# Altium schematic sheet 05-SPI.SchDoc
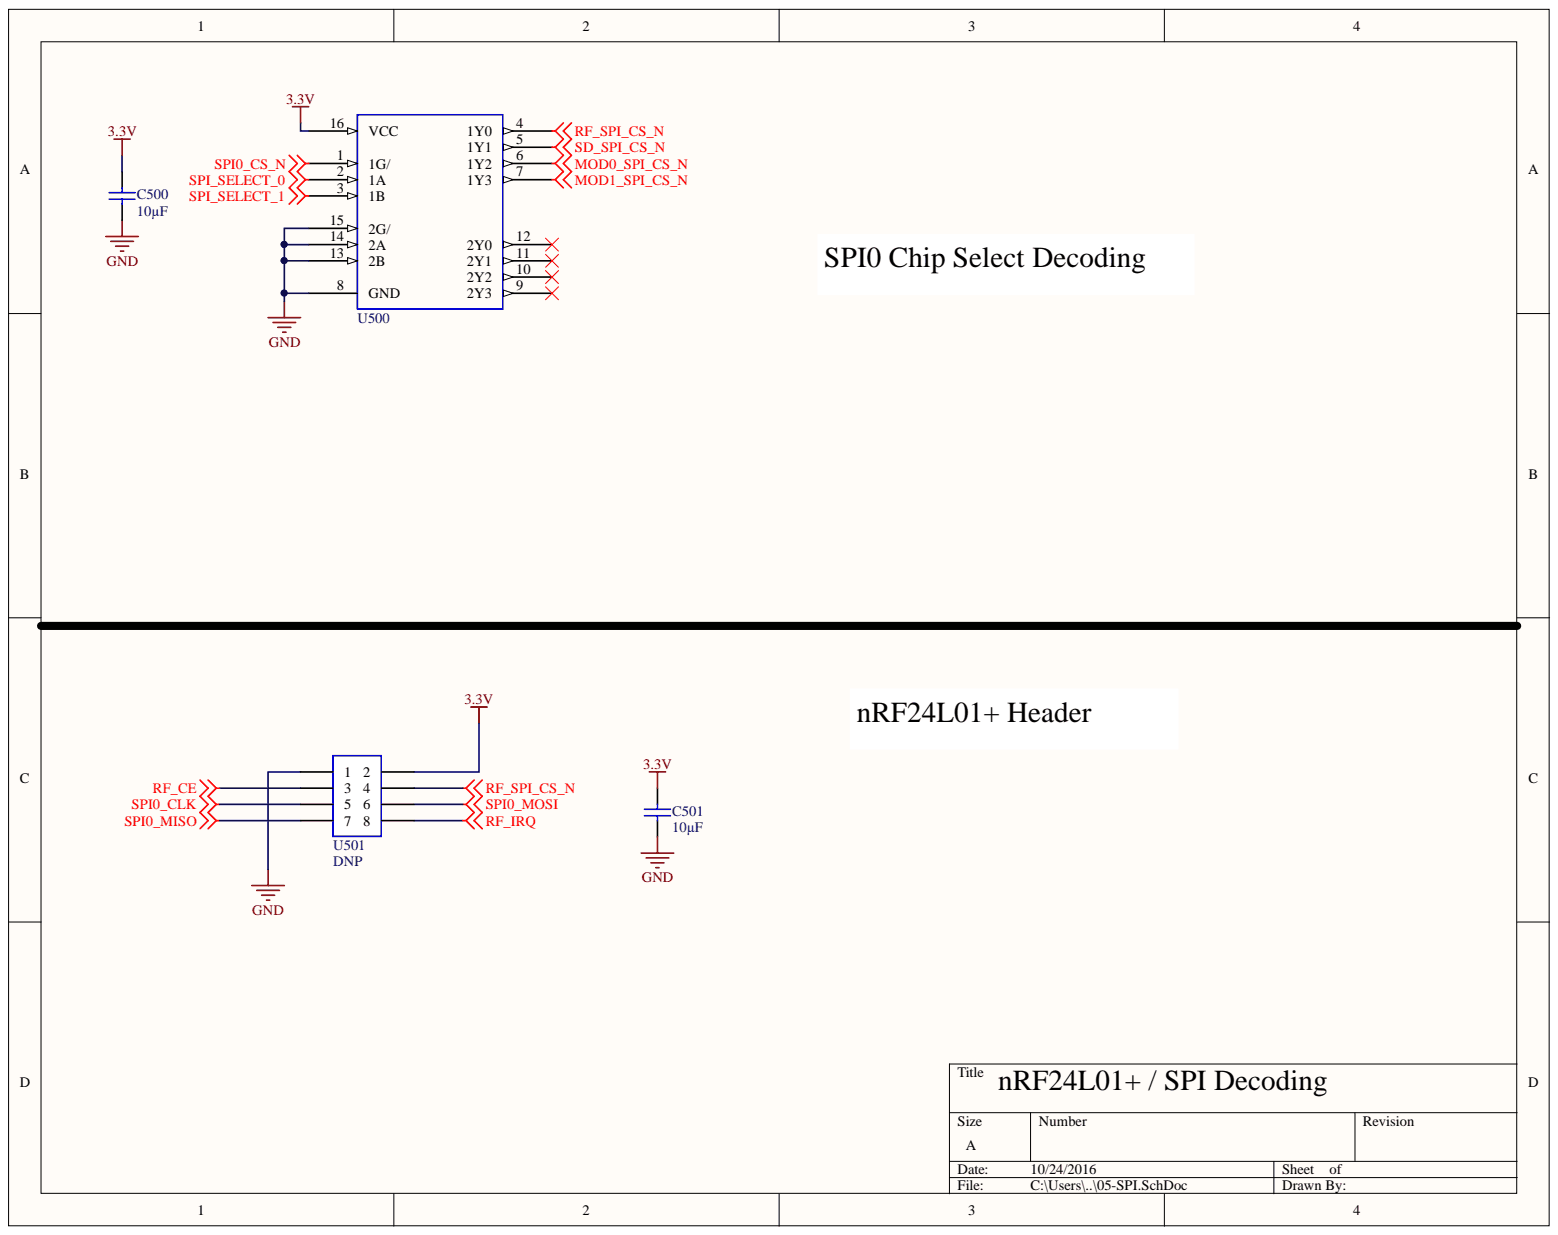
[diagram p0: 05-SPI.SchDoc]

## Parts
C500  10µF  [0805]
C501  10µF  [0805]
U500  IC_SN74LV139A  [SOIC-16N]  (value not drawn)
U501  nRF24L01+  Usage: DNP  [HDR2X4]

## Nets
3.3V: C500.2 C501.2 U500.16(VCC) U501.2
GND: C500.1 C501.1 U500.8(GND) U500.13(2B) U500.14(2A) U500.15(2G/) U501.1
MOD0_SPI_CS_N: U500.6(1Y2)
MOD1_SPI_CS_N: U500.7(1Y3)
RF_CE: U501.3
RF_IRQ: U501.8
RF_SPI_CS_N: U500.4(1Y0) U501.4
SD_SPI_CS_N: U500.5(1Y1)
SPI0_CLK: U501.5
SPI0_CS_N: U500.1(1G/)
SPI0_MISO: U501.7
SPI0_MOSI: U501.6
SPI_SELECT_0: U500.2(1A)
SPI_SELECT_1: U500.3(1B)

Not connected: U500 9(2Y3) 10(2Y2) 11(2Y1) 12(2Y0)

## Library data of the parts
C500 C501 = CAP_0805_U_010.000: CAP CER 10UF 16V 10% X5R 0805; Manufacturer: Murata Electronics North America; Manufacturer Part Number: GRM21BR61C106KE15L; Applications: General Purpose; Capacitance: 10µF; Category: Capacitors; Component Kind: Standard; Features: -; Height - Seated (Max): -; Lead Spacing: -; Lead Style: -; Library Name: ece353-2016.SchLib; Mounting Type: Surface Mount, MLCC; Operating Temperature: -55°C ~ 85°C; Package / Case: 0805 (2012 Metric); Packaging: Cut Tape (CT); Ratings: -; RoHS: RoHS Compliant; Size / Dimension: 0.079" L x 0.049" W (2.00mm x 1.25mm); Supplier: Digi-Key; Supplier 1: Digi-Key; Supplier Part Number: 490-3886-1-ND; Supplier Part Number 1: 490-3886-1-ND; Temperature Coefficient: X5R; Thickness (Max): 0.053" (1.35mm); Tolerance: ±10%; Value: 10µF; Voltage - Rated: 16V
U500 = IC_SN74LV139A: DUAL 2-LINE TO 4-LINE DECODERS/DEMULTIPLEXERS; Manufacturer: Texas Instruments; Manufacturer Part Number: SN74LV139ADR; Circuit: 1 x 2:4; Current - Output High, Low: 12mA, 12mA; Independent Circuits: 2; Voltage - Supply: 2 V ~ 5.5 V; Voltage Supply Source: Single Supply; Category: Integrated Circuits (ICs); Component Kind: Standard; Library Name: ece353-2016.SchLib; Mounting Type: Surface Mount; Operating Temperature: -40°C ~ 85°C; Package / Case: 16-SOIC (0.154", 3.90mm Width); Packaging: Cut Tape (CT); RoHS: RoHS Compliant; Supplier: Digi-Key; Supplier 1: Digi-Key; Supplier Device Package: 16-SOIC; Supplier Part Number: 296-31866-1-ND; Supplier Part Number 1: 296-31866-1-ND; Type: Decoder/Demultiplexer
U501 = nRF24L01+: Manufacturer Part Number: Ebay nRF24L01+; Component Kind: Standard; Description: nRF24L01+; Library Name: ece353-2016.SchLib
